# Altium schematic sheet Main.SchDoc
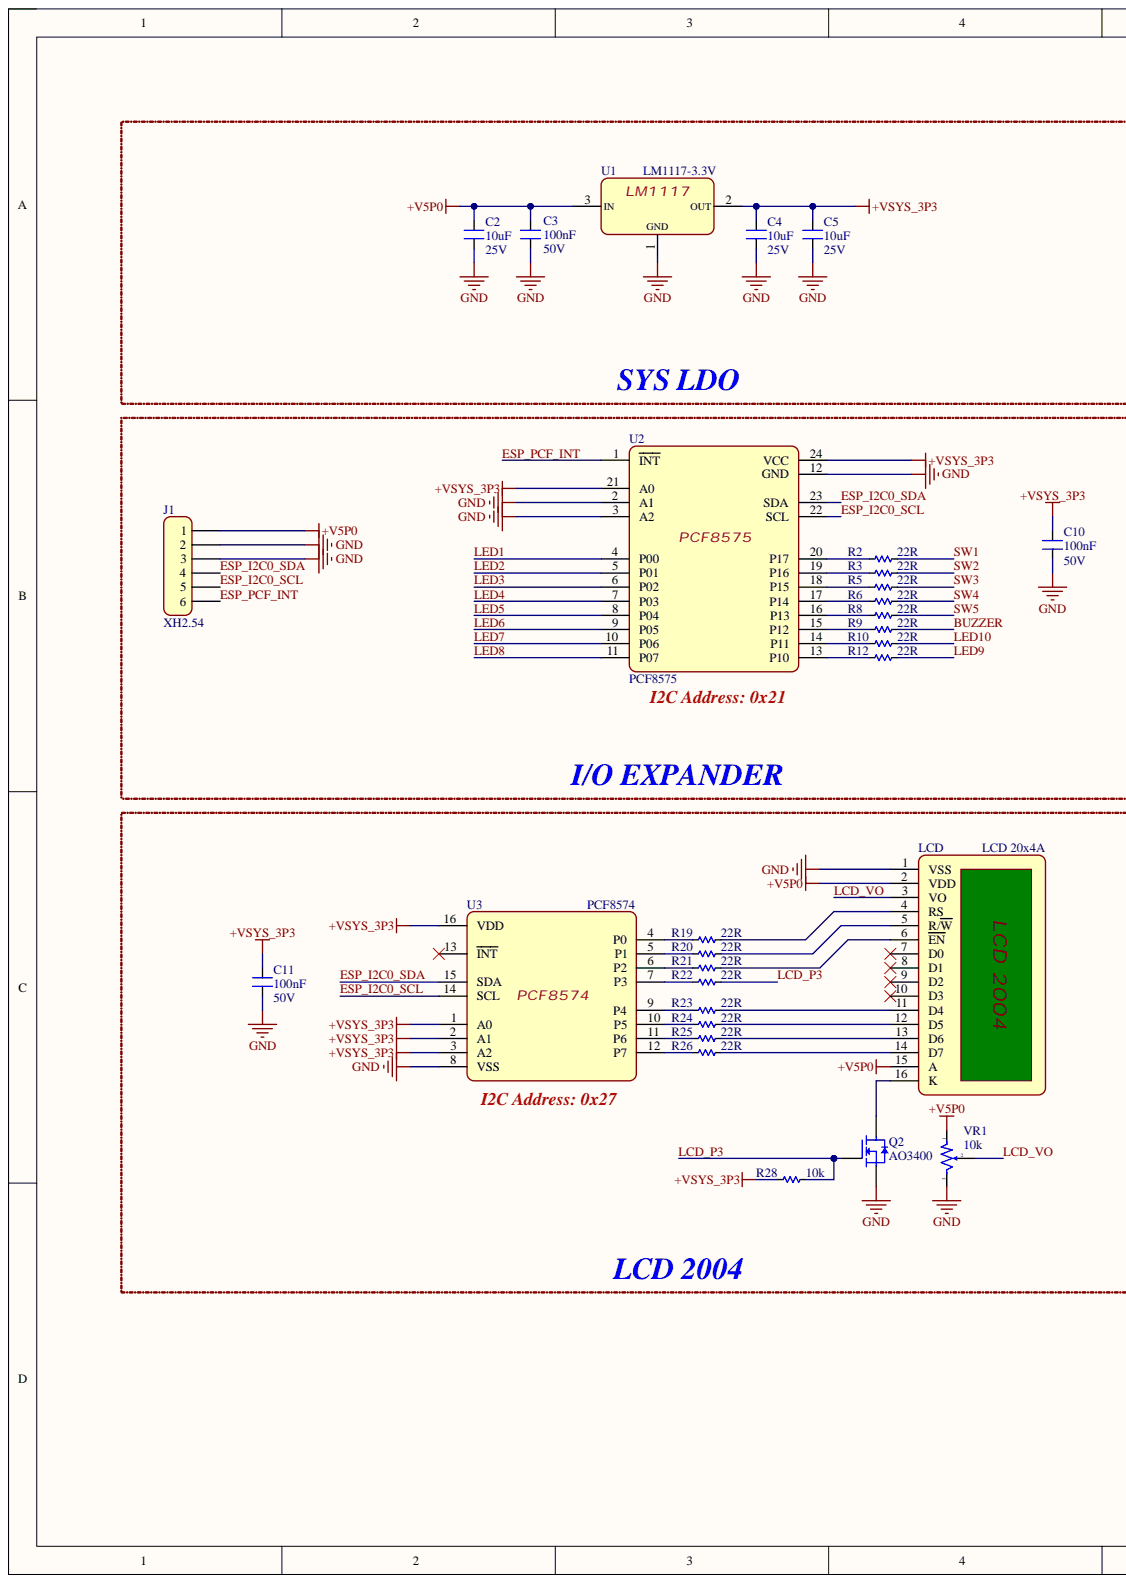
[diagram p0: Main.SchDoc, left]
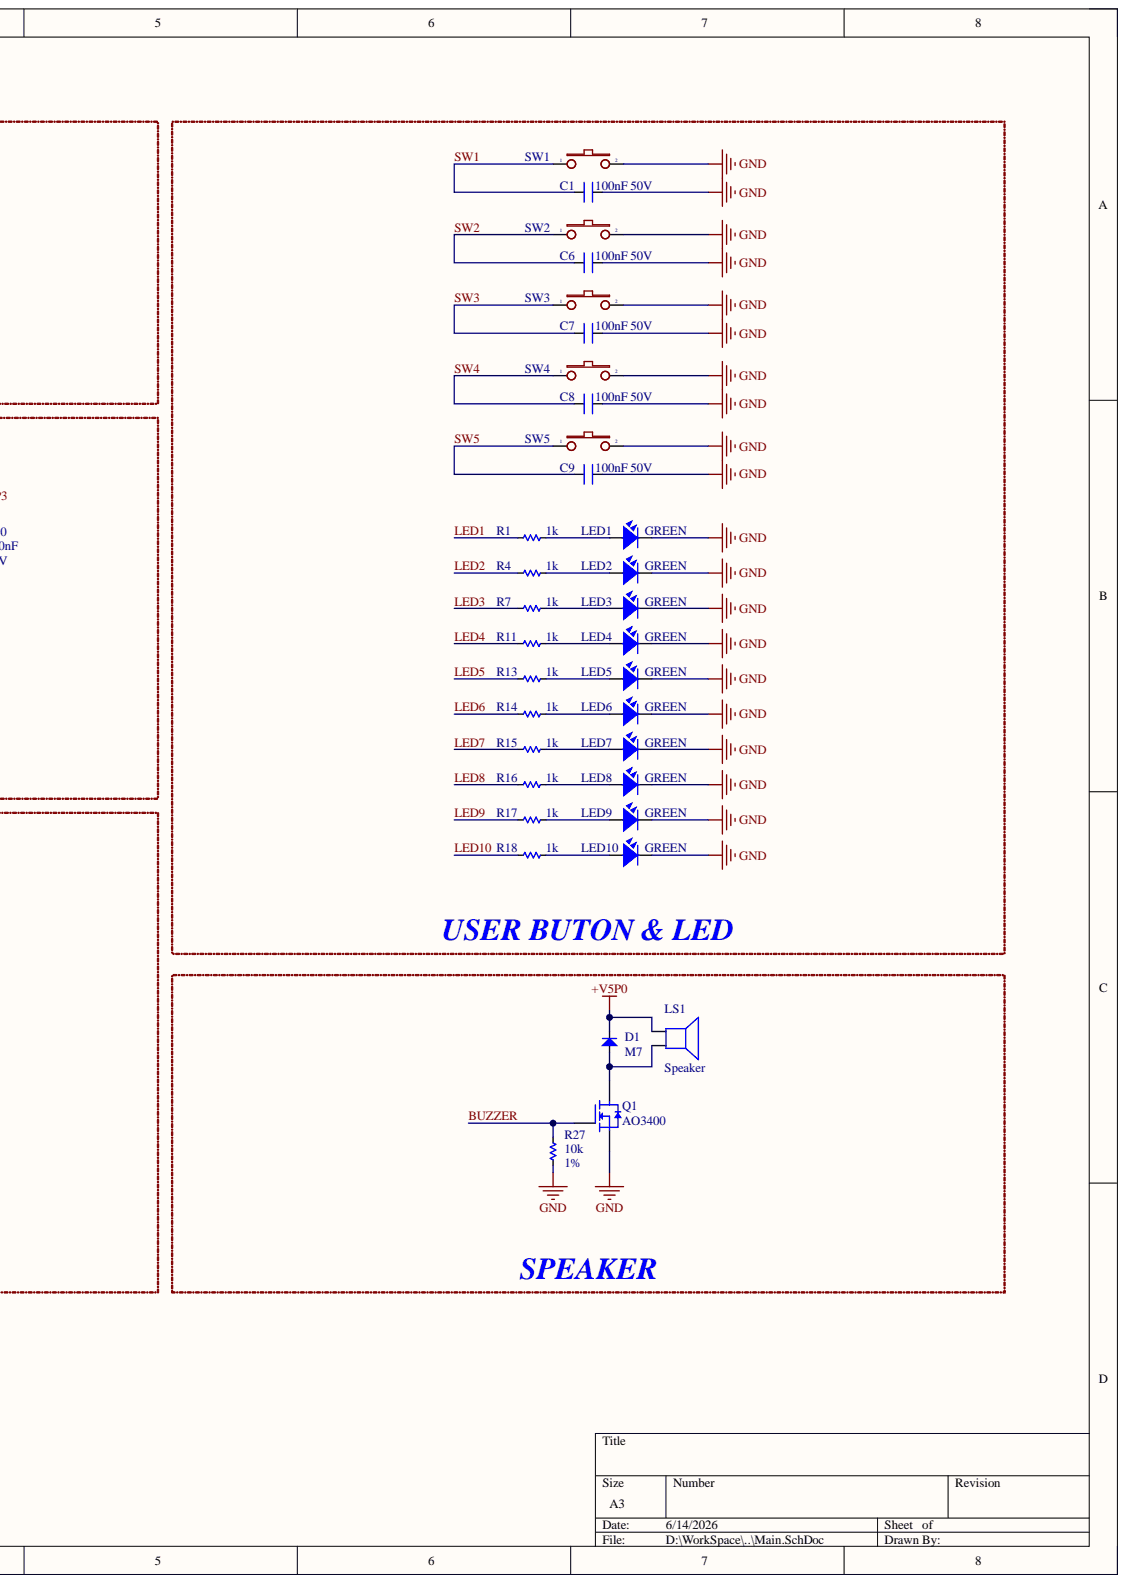
[diagram p1: Main.SchDoc, right]

## Parts
C1  100nF  [CAP_SMD_0603]
C2  10uF  Rating: 25V  [CAP_SMD_1206]
C3  100nF  Rating: 50V  [CAP_SMD_0603]
C4  10uF  Rating: 25V  [CAP_SMD_1206]
C5  10uF  Rating: 25V  [CAP_SMD_1206]
C6  100nF  [CAP_SMD_0603]
C7  100nF  Rating: 50V  [CAP_SMD_0603]
C8  100nF  [CAP_SMD_0603]
C9  100nF  [CAP_SMD_0603]
C10  100nF  Rating: 50V  [CAP_SMD_0603]
C11  100nF  Rating: 50V  [CAP_SMD_0603]
D1  M7  [DIO-SMD-A]
J1  XH2.54  [JP6-C1T]
LCD  LCD 20x4A  [LCD20X4A]
LED1  GREEN  [GREENLED_0805]
LED2  GREEN  [GREENLED_0805]
LED3  GREEN  [GREENLED_0805]
LED4  GREEN  [GREENLED_0805]
LED5  GREEN  [GREENLED_0805]
LED6  GREEN  [GREENLED_0805]
LED7  GREEN  [GREENLED_0805]
LED8  GREEN  [GREENLED_0805]
LED9  GREEN  [GREENLED_0805]
LED10  GREEN  [GREENLED_0805]
LS1  Speaker  [SPEAKER]
Q1  AO3400  [SOT23-132]
Q2  AO3400  [SOT23-132]
R1  1k  [RES_SMD_0603]
R2  22R  [RES_SMD_0603]
R3  22R  [RES_SMD_0603]
R4  1k  [RES_SMD_0603]
R5  22R  [RES_SMD_0603]
R6  22R  [RES_SMD_0603]
R7  1k  [RES_SMD_0603]
R8  22R  [RES_SMD_0603]
R9  22R  [RES_SMD_0603]
R10  22R  [RES_SMD_0603]
R11  1k  [RES_SMD_0603]
R12  22R  [RES_SMD_0603]
R13  1k  [RES_SMD_0603]
R14  1k  [RES_SMD_0603]
R15  1k  [RES_SMD_0603]
R16  1k  [RES_SMD_0603]
R17  1k  [RES_SMD_0603]
R18  1k  [RES_SMD_0603]
R19  22R  [RES_SMD_0603]
R20  22R  [RES_SMD_0603]
R21  22R  [RES_SMD_0603]
R22  22R  [RES_SMD_0603]
R23  22R  [RES_SMD_0603]
R24  22R  [RES_SMD_0603]
R25  22R  [RES_SMD_0603]
R26  22R  [RES_SMD_0603]
R27  10k  Tolerance: 1%  [RES_SMD_0603]
R28  10k  [RES_SMD_0603]
SW1  SW2  [SW_12x12]  (value not drawn)
SW2  SW2  [SW_12x12]
SW3  SW2  [SW_12x12]  (value not drawn)
SW4  SW2  [SW_12x12]  (value not drawn)
SW5  SW2  [SW_12x12]  (value not drawn)
U1  LM1117-3.3V  [SOT223-4]
U2  PCF8575  [SSOP-24-208mil]
U3  PCF8574  [SOIC-16-300mil]
VR1  10k  [VR-D8]

## Nets
+V5P0: C2.1 C3.2 D1.2 J1.1 LCD.2(VDD) LCD.15(A) LS1.1 U1.3 VR1.1
+VSYS_3P3: C4.1 C5.1 C10.1 C11.1 R28.2 U1.2 U2.21(A0) U2.24(VCC) U3.1(A0) U3.2(A1) U3.3(A2) U3.16(VDD)
GND: C1.2 C2.2 C3.1 C4.2 C5.2 C6.2 C7.2 C8.2 C9.2 C10.2 C11.2 J1.2 J1.3 LCD.1(VSS) LED1.2 LED2.2 LED3.2 LED4.2 LED5.2 LED6.2 LED7.2 LED8.2 LED9.2 LED10.2 Q1.2 Q2.2 R27.2 SW1.2 SW2.2 SW3.2 SW4.2 SW5.2 U1.1 U2.2(A1) U2.3(A2) U2.12(GND) U3.8(VSS) VR1.3
BUZZER: Q1.1 R9.1 R27.1
ESP_I2C0_SCL: J1.5 U2.22(SCL) U3.14(SCL)
ESP_I2C0_SDA: J1.4 U2.23(SDA) U3.15(SDA)
ESP_PCF_INT: J1.6 U2.1(INT)
LCD_P3: Q2.1 R22.1 R28.1
LCD_VO: LCD.3(VO) VR1.2
LED1: R1.2 U2.4(P00)
LED2: R4.2 U2.5(P01)
LED3: R7.2 U2.6(P02)
LED4: R11.2 U2.7(P03)
LED5: R13.2 U2.8(P04)
LED6: R14.2 U2.9(P05)
LED7: R15.2 U2.10(P06)
LED8: R16.2 U2.11(P07)
LED9: R12.1 R17.2
LED10: R10.1 R18.2
SW1: C1.1 R2.1 SW1.1
SW2: C6.1 R3.1 SW2.1
SW3: C7.1 R5.1 SW3.1
SW4: C8.1 R6.1 SW4.1
SW5: C9.1 R8.1 SW5.1
NetD1_1: D1.1 LS1.2 Q1.3
NetLCD_4: LCD.4(RS) R19.1
NetLCD_5: LCD.5(R/W) R20.1
NetLCD_6: LCD.6(EN) R21.1
NetLCD_11: LCD.11(D4) R23.1
NetLCD_12: LCD.12(D5) R24.1
NetLCD_13: LCD.13(D6) R25.1
NetLCD_14: LCD.14(D7) R26.1
NetLCD_16: LCD.16(K) Q2.3
NetLED1_1: LED1.1 R1.1
NetLED2_1: LED2.1 R4.1
NetLED3_1: LED3.1 R7.1
NetLED4_1: LED4.1 R11.1
NetLED5_1: LED5.1 R13.1
NetLED6_1: LED6.1 R14.1
NetLED7_1: LED7.1 R15.1
NetLED8_1: LED8.1 R16.1
NetLED9_1: LED9.1 R17.1
NetLED10_1: LED10.1 R18.1
NetR2_2: R2.2 U2.20(P17)
NetR3_2: R3.2 U2.19(P16)
NetR5_2: R5.2 U2.18(P15)
NetR6_2: R6.2 U2.17(P14)
NetR8_2: R8.2 U2.16(P13)
NetR9_2: R9.2 U2.15(P12)
NetR10_2: R10.2 U2.14(P11)
NetR12_2: R12.2 U2.13(P10)
NetR19_2: R19.2 U3.4(P0)
NetR20_2: R20.2 U3.5(P1)
NetR21_2: R21.2 U3.6(P2)
NetR22_2: R22.2 U3.7(P3)
NetR23_2: R23.2 U3.9(P4)
NetR24_2: R24.2 U3.10(P5)
NetR25_2: R25.2 U3.11(P6)
NetR26_2: R26.2 U3.12(P7)

Not connected: LCD 7(D0) 8(D1) 9(D2) 10(D3); U3 13(INT)

## Library data of the parts
C1 C6 C8 C9 = CN: Rating: 50V; Component Kind: Standard; Value: 100nF; Library Name: Library_HUNG.SchLib
C2-C5 C7 C10 C11 = CN: Component Kind: Standard; Library Name: Library_HUNG.SchLib
  C2 C4 C5: Value: 10uF
  C3 C7 C10 C11: Value: 100nF
D1 = Diode SMD: Default Diode; Component Kind: Standard; Value: M7; Library Name: Library_HUNG.SchLib
J1 = JP6: ComponentLink1 Description: Manufacturer; ComponentLink2 Description: Datasheet; Component Kind: Standard; ComponentLink1URL: http://www.we-online.com; ComponentLink2URL: http://katalog.we-online.de/em/datasheet/6130xx143121.pdf; Value: XH2.54; Library Name: Library_HUNG.SchLib
LCD = LCD20x4A: LCD dipslay 20 char, 4 line; Component Kind: Standard; Value: LCD 20x4A; Library Name: Library_HUNG.SchLib
LED1-LED10 = LED SMD: Component Kind: Standard; Value: GREEN; Library Name: Library_HUNG.SchLib
LS1 = Speaker: Loudspeaker; Component Kind: Standard; LatestRevisionDate: 17-Jul-2002; LatestRevisionNote: Re-released for DXP Platform.; PackageReference: PIN2; Published: 24-Mar-1999; Library Name: Library_HUNG.SchLib; Publisher: Altium Limited
Q1 Q2 = FET-N: Component Kind: Standard; Value: AO3400; Library Name: Library_HUNG.SchLib
R1-R26 R28 = Res-SMD: Resistor; Tolerance: 1%; Component Kind: Standard; Library Name: Library_HUNG.SchLib
  R1 R4 R7 R11 R13-R18: Value: 1k
  R2 R3 R5 R6 R8-R10 R12 R19-R26: Value: 22R
  R28: Value: 10k
R27 = Res-SMD: Resistor; Component Kind: Standard; Value: 10k; Library Name: Library_HUNG.SchLib
SW1-SW5 = SW2: Switch button 2 pin; PN: B3U-3000P; Component Kind: Standard; LCSC: C963349; Value: SW2; Library Name: Library_HUNG.SchLib
U1 = LM1117: 800mA Low-Dropout Linear Regulator; PN: LM1117MPX-3.3/NOPB; Component Kind: Standard; LCSC: C9662; Value: LM1117-3.3V; Library Name: Library_HUNG.SchLib
U2 = PCF8575: -40℃~+85℃ 10uA 16 2.5V~5.5V 25mA 300uA 400kHz 8 Cascading and address extension、Built-in LED driver I2C Interrupt Output SSOP-24-208mil I/O Expanders ROHS; PN: PCF8575TS/1,118; Component Kind: Standard; LCSC: C12251; Value: PCF8575; Library Name: Library_HUNG.SchLib
U3 = PCF8574: -40℃~+85℃ 100kHz 10mA 16 2.5V~6V 2.5uA 30uA 8 I2C Interrupt Output Reset function、Cascading and address extension、Built-in LED driver SOIC-16-300mil I/O Expanders ROHS; PN: PCF8574T/3,518; Component Kind: Standard; LCSC: C7605; Value: PCF8574; Library Name: Library_HUNG.SchLib
VR1 = VR-S: Var Resistor; Component Kind: Standard; Value: 10k; Library Name: Library_HUNG.SchLib
